# Altium schematic sheet STMH7.SchDoc(STMH7)
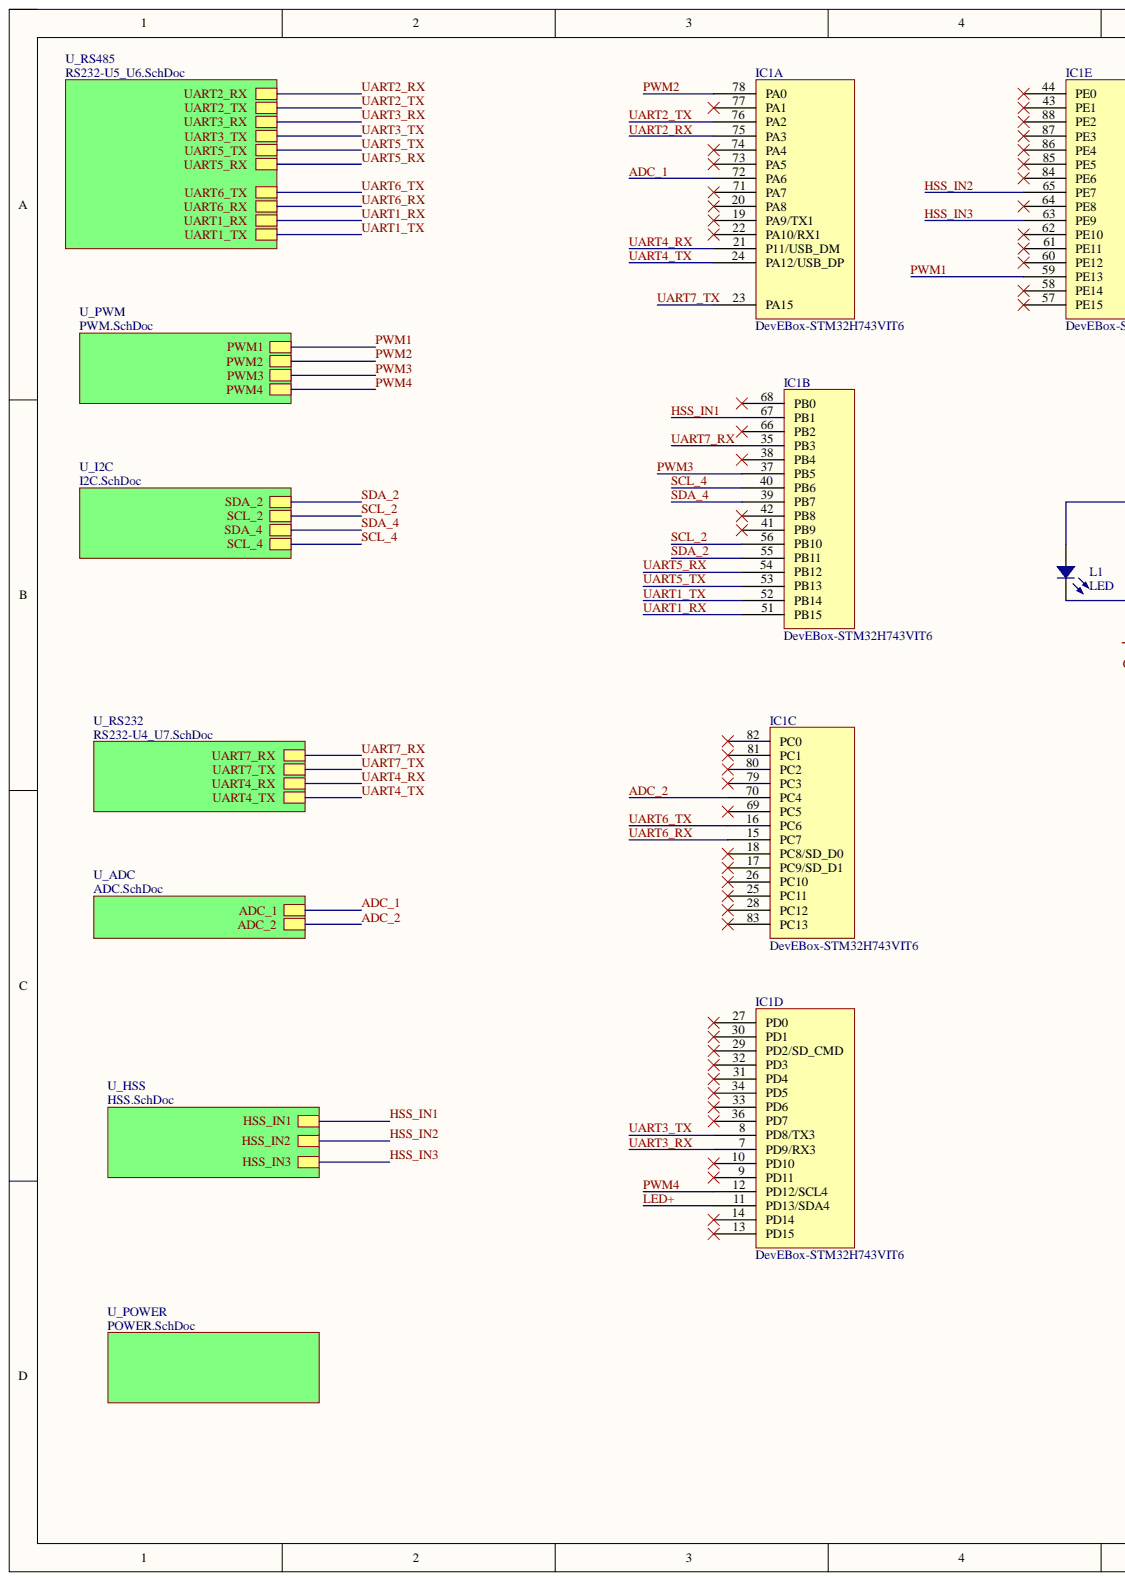
[diagram p0: STMH7.SchDoc, left]
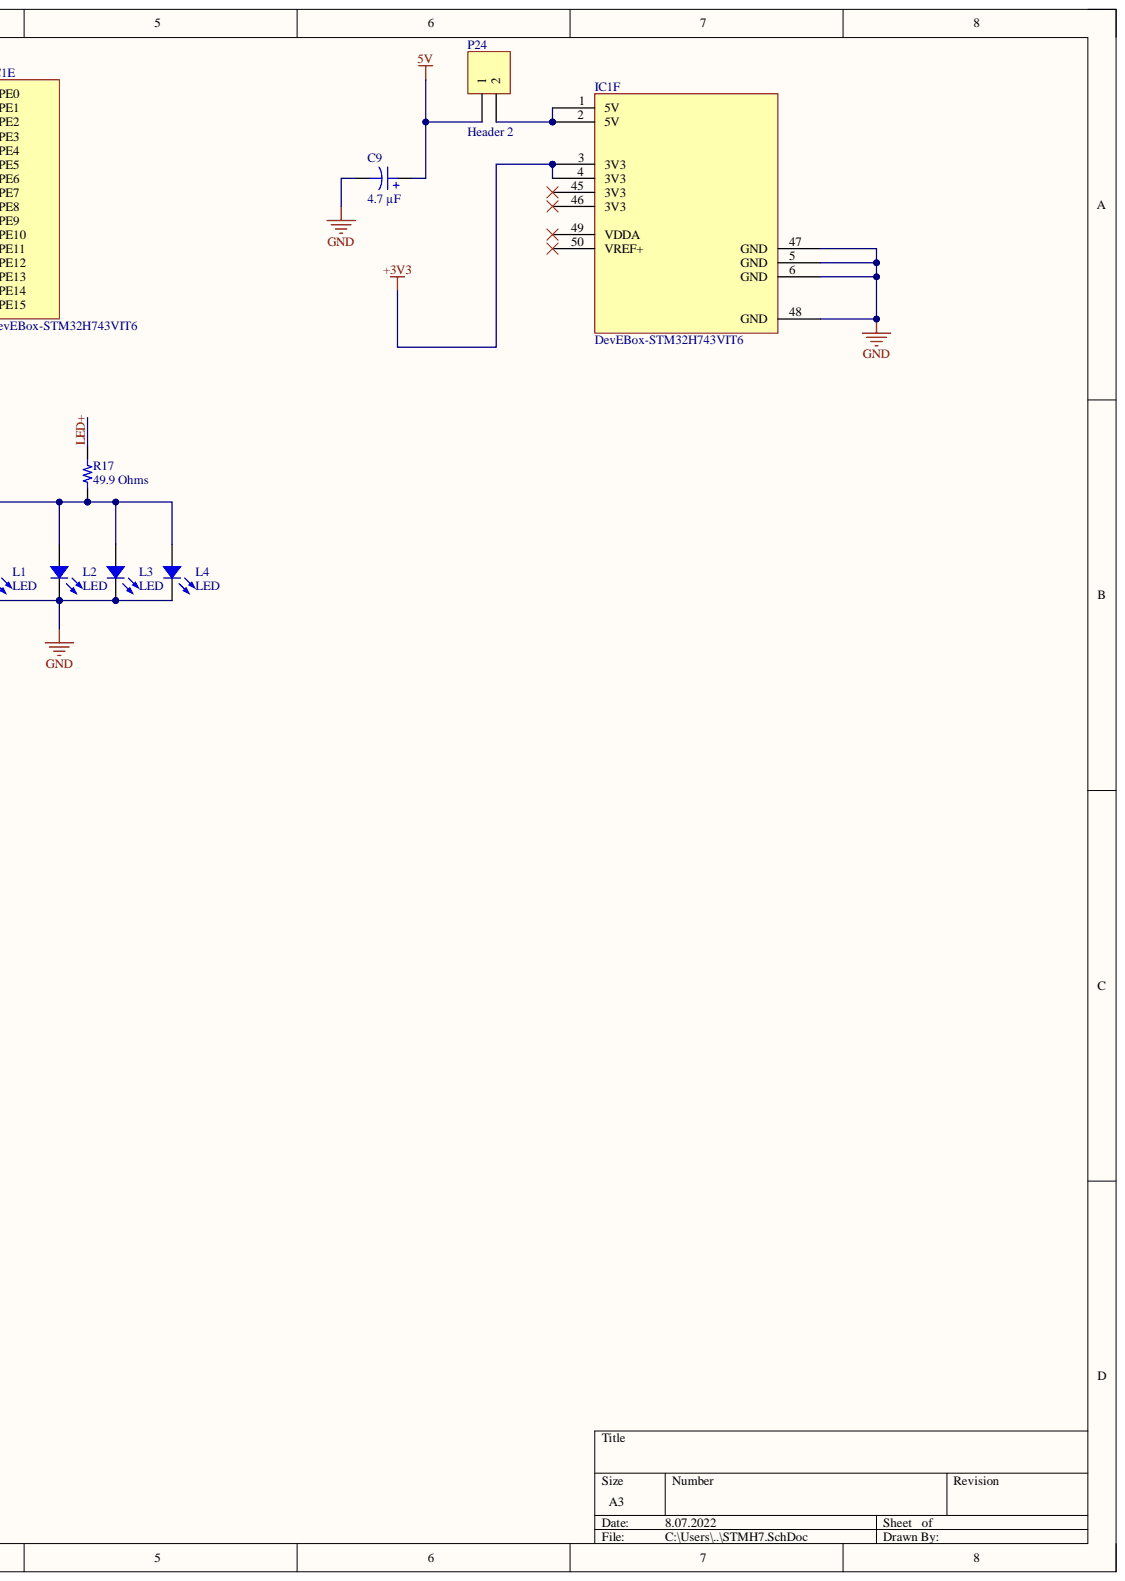
[diagram p1: STMH7.SchDoc, right]

## Parts
C9  4.7 µF  [CAP ALSMD 4.3MM H5.4MM]
IC1  DevEBox-STM32H743VIT6  [DevEBox-STM32H743VIT6]  (parts A,B,C,D,E,F)
L1  LED  [LED]
L2  LED  [LED]
L3  LED  [LED]
L4  LED  [LED]
P24  Header 2  [HDR1X2]
R17  49.9 Ohms  [RES 1206_3216 RF]

## Nets
5V: C9.1 P24.1
+3V3: IC1.3(3V3) IC1.4(3V3)
GND: C9.2 IC1.5(GND) IC1.6(GND) IC1.47(GND) IC1.48(GND) L1.2 L2.2 L3.2 L4.2
ADC_1: IC1.72(PA6)
ADC_2: IC1.70(PC4)
HSS_IN1: IC1.67(PB1)
HSS_IN2: IC1.65(PE7)
HSS_IN3: IC1.63(PE9)
LED+: IC1.11(PD13/SDA4) R17.2
PWM1: IC1.59(PE13)
PWM2: IC1.78(PA0)
PWM3: IC1.37(PB5)
PWM4: IC1.12(PD12/SCL4)
SCL_2: IC1.56(PB10)
SCL_4: IC1.40(PB6)
SDA_2: IC1.55(PB11)
SDA_4: IC1.39(PB7)
UART1_RX: IC1.51(PB15)
UART1_TX: IC1.52(PB14)
UART2_RX: IC1.75(PA3)
UART2_TX: IC1.76(PA2)
UART3_RX: IC1.7(PD9/RX3)
UART3_TX: IC1.8(PD8/TX3)
UART4_RX: IC1.21(P11/USB_DM)
UART4_TX: IC1.24(PA12/USB_DP)
UART5_RX: IC1.54(PB12)
UART5_TX: IC1.53(PB13)
UART6_RX: IC1.15(PC7)
UART6_TX: IC1.16(PC6)
UART7_RX: IC1.35(PB3)
UART7_TX: IC1.23(PA15)
NetIC1_9: IC1.9(PD11)
NetIC1_10: IC1.10(PD10)
NetIC1_13: IC1.13(PD15)
NetIC1_14: IC1.14(PD14)
NetIC1_17: IC1.17(PC9/SD_D1)
NetIC1_18: IC1.18(PC8/SD_D0)
NetIC1_19: IC1.19(PA9/TX1)
NetIC1_20: IC1.20(PA8)
NetIC1_22: IC1.22(PA10/RX1)
NetIC1_25: IC1.25(PC11)
NetIC1_26: IC1.26(PC10)
NetIC1_27: IC1.27(PD0)
NetIC1_28: IC1.28(PC12)
NetIC1_29: IC1.29(PD2/SD_CMD)
NetIC1_30: IC1.30(PD1)
NetIC1_31: IC1.31(PD4)
NetIC1_32: IC1.32(PD3)
NetIC1_33: IC1.33(PD6)
NetIC1_34: IC1.34(PD5)
NetIC1_36: IC1.36(PD7)
NetIC1_38: IC1.38(PB4)
NetIC1_41: IC1.41(PB9)
NetIC1_42: IC1.42(PB8)
NetIC1_43: IC1.43(PE1)
NetIC1_44: IC1.44(PE0)
NetIC1_45: IC1.45(3V3)
NetIC1_46: IC1.46(3V3)
NetIC1_49: IC1.49(VDDA)
NetIC1_50: IC1.50(VREF+)
NetIC1_57: IC1.57(PE15)
NetIC1_58: IC1.58(PE14)
NetIC1_60: IC1.60(PE12)
NetIC1_61: IC1.61(PE11)
NetIC1_62: IC1.62(PE10)
NetIC1_64: IC1.64(PE8)
NetIC1_66: IC1.66(PB2)
NetIC1_68: IC1.68(PB0)
NetIC1_69: IC1.69(PC5)
NetIC1_71: IC1.71(PA7)
NetIC1_73: IC1.73(PA5)
NetIC1_74: IC1.74(PA4)
NetIC1_77: IC1.77(PA1)
NetIC1_79: IC1.79(PC3)
NetIC1_80: IC1.80(PC2)
NetIC1_81: IC1.81(PC1)
NetIC1_82: IC1.82(PC0)
NetIC1_83: IC1.83(PC13)
NetIC1_84: IC1.84(PE6)
NetIC1_85: IC1.85(PE5)
NetIC1_86: IC1.86(PE4)
NetIC1_87: IC1.87(PE3)
NetIC1_88: IC1.88(PE2)
NetL1_1: L1.1 L2.1 L3.1 L4.1 R17.1
NetP24_2: IC1.1(5V) IC1.2(5V) P24.2

## Library data of the parts
C9 = EMVE350ADA4R7MD55G: CAP ALUM 4.7UF 20% 35V SMD; manufacturer: United Chemi-Con; Database Table Name: Capacitors - Aluminum - Electrolytic SMT; Lifetime @ Temp.: 1000 Hrs @ 105°C; Polarization: Polar; Ripple Current @ Low Frequency: 16 mA @ 120 Hz; Surface Mount Land Size: 0.169" L x 0.169" W (4.30mm x 4.30mm); Applications: General Purpose; Capacitance: 4.7 µF; Component Kind: Standard; componentlink1description: Datasheet; componentlink1url: //media.digikey.com/pdf/Data%20Sheets/United%20Chemi-Con%20PDFs/MVE%20Series.pdf; componentlink2description: DigiKey Link; componentlink2url: https://www.digikey.com/en/products/detail/united-chemi-con/EMVE350ADA4R7MD55G/756875; Device Package: Radial, Can - SMD; Height - Seated (Max): 0.205" (5.20mm); lastupdated: 15.03.2022 03:52:48; Library Name: Celestial Altium Library - altium_library.DbLib; Lifecycle Status: Active; Minimum Order: 1; Mounting Type: Surface Mount; Operating Temperature: -40°C ~ 105°C; Package / Case: Radial, Can - SMD; packaging: TapeAndReel; Part Number: EMVE350ADA4R7MD55G; Part Status: Active; partid: 7039; price: 0.09; series: Alchip™- MVE; Size / Dimension: 0.157" Dia (4.00mm); Supplier 1: DigiKey; Supplier Part Number 1: 565-2229-1-ND; Tolerance: ±20%; value: 4.7 µF; Voltage - Rated: 35 V
P24 = Header 2: Header, 2-Pin; Component Kind: Standard; LatestRevisionDate: 17-Jul-2002; LatestRevisionNote: Re-released for DXP Platform.; Library Name: Miscellaneous Connectors.IntLib; Signal Integrity: Connector; Publisher: Altium Limited
R17 = RT1206BRD0749R9L: RES SMD 49.9 OHM 0.1% 1/4W 1206; Manufacturer: Yageo; Database Table Name: Resistors - Surface Mount - 1206; Power (Watts): 0.25W, 1/4W; Temperature Coefficient: ±25ppm/°C; Component Kind: Standard; ComponentLink1Description: Datasheet; ComponentLink1URL: https://www.yageo.com/upload/media/product/productsearch/datasheet/rchip/PYu-RT_1-to-0.01_RoHS_L_11.pdf; ComponentLink2Description: DigiKey Link; ComponentLink2URL: https://www.digikey.com/en/products/detail/yageo/RT1206BRD0749R9L/1079849; Composition: Thin Film; Device Package: 1206 (3216 Metric); Height - Seated (Max): 0.026" (0.65mm); LastUpdated: 4.08.2022 05:00:43; Library Name: Celestial Altium Library - altium_library.DbLib; Lifecycle Status: Active; Minimum Order: 1; Number of Terminations: 2; Operating Temperature: -55°C ~ 155°C; Package / Case: 1206 (3216 Metric); Packaging: TapeAndReel; Part Number: RT1206BRD0749R9L; Part Status: Active; PartId: 26863; Price: 0.10; Resistance: 49.9 Ohms; Series: RT; Size / Dimension: 0.122" L x 0.063" W (3.10mm x 1.60mm); Supplier 1: DigiKey; Supplier Device Package: 1206; Supplier Part Number 1: YAG5080CT-ND; Tolerance: ±0.1%; Value: 49.9 Ohms
IC1 = DevEBox-STM32H743VIT6: Component Kind: Standard; Library Name: Schlib1.SchLib
L1-L4 = LED: Component Kind: Standard; Library Name: Schlib1.SchLib
